# Altium schematic sheet 9xVL53L1X.SchDoc
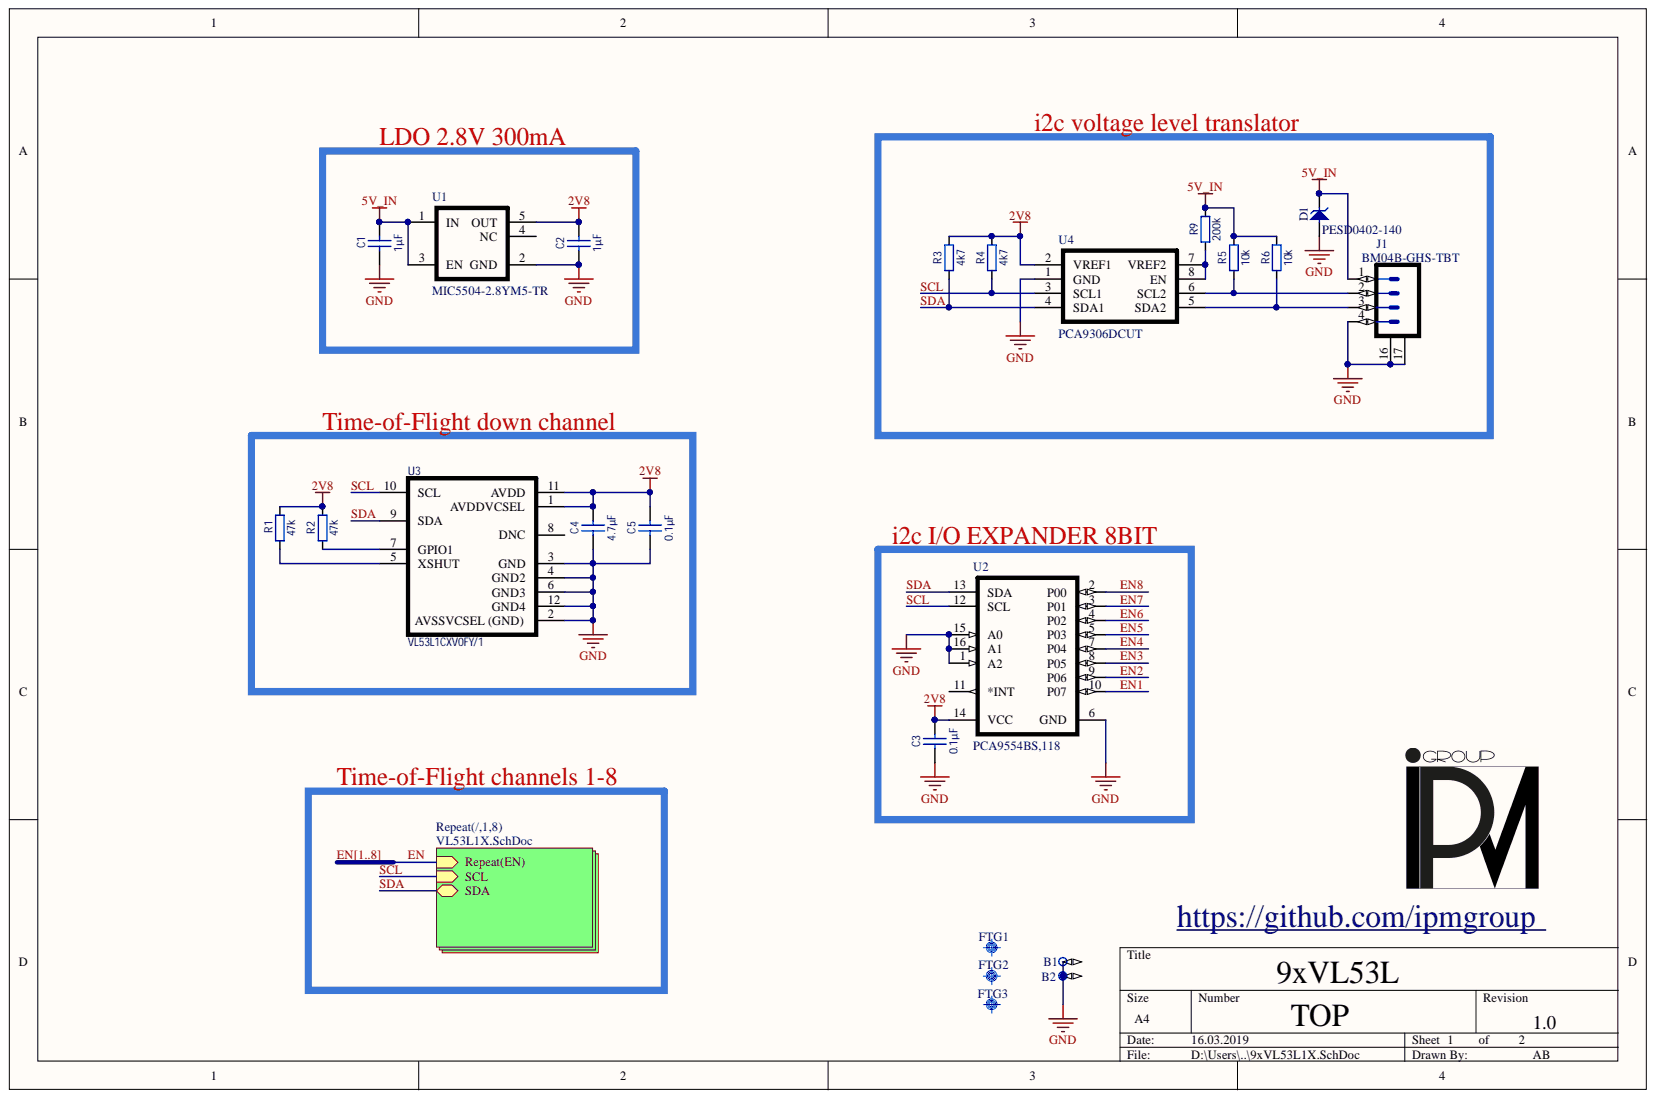
[diagram p0: 9xVL53L1X.SchDoc]

## Parts
B1  Plated Hole  [c300h160]  (value not drawn)  (no BOM)
B2  Plated Hole  [c300h160]  (value not drawn)  (no BOM)
C1  1µF  [CAPC1005X55N]
C2  1µF  [CAPC1005X55N]
C3  0.1µF  [CAPC1005X55N]
C4  4.7µF  [CAPC1005X55N]
C5  0.1µF  [CAPC1005X55N]
D1  PESD0402-140  [0402]
FTG1  Use only in PCB  [FIDUCIAL_TOP_C100-200]  (value not drawn)  (no BOM)
FTG2  Use only in PCB  [FIDUCIAL_TOP_C100-200]  (value not drawn)  (no BOM)
FTG3  Use only in PCB  [FIDUCIAL_TOP_C100-200]  (value not drawn)  (no BOM)
J1  BM04B-GHS-TBT  [JST_BM04B]
R1  47k  [RESC1005X40N]
R2  47k  [RESC1005X40N]
R3  4k7  [RESC1005X40N]
R4  4k7  [RESC1005X40N]
R5  10k  [RESC1005X40N]
R6  10k  [RESC1005X40N]
R9  200k  [RESC1005X40N]
U1  MIC5504-2.8YM5-TR  [SOT95P280X145-5N]
U2  PCA9554BS,118  [QFN65P400X400X100_HS-17N]
U3  VL53L1CXV0FY/1  [LGA12C80P3X5_250X490X156]
U4  PCA9306DCUT  [DCU8]

## Nets
2V8: C2.2 C3.2 C4.2 C5.2 R1.2 R2.2 R3.2 R4.2 U1.5(OUT) U2.14(VCC) U3.1(AVDDVCSEL) U3.11(AVDD) U4.2(VREF1)
5V_IN: C1.2 D1.1 J1.1 R5.2 R6.2 R9.2 U1.1(IN) U1.3(EN)
GND: B1.1 B2.1 C1.1 C2.1 C3.1 C4.1 C5.1 D1.2 J1.4 J1.16 J1.17 U1.2(GND) U2.1(A2) U2.6(GND) U2.15(A0) U2.16(A1) U3.2(AVSSVCSEL_(GND)) U3.3(GND) U3.4(GND2) U3.6(GND3) U3.12(GND4) U4.1(GND)
EN1: U2.10(P07)  [also labelled EN]
EN2: U2.9(P06)  [also labelled EN]
EN3: U2.8(P05)  [also labelled EN]
EN4: U2.7(P04)  [also labelled EN]
EN5: U2.5(P03)  [also labelled EN]
EN6: U2.4(P02)  [also labelled EN]
EN7: U2.3(P01)  [also labelled EN]
EN8: U2.2(P00)  [also labelled EN]
SCL: R4.1 U2.12(SCL) U3.10(SCL) U4.3(SCL1)
SDA: R3.1 U2.13(SDA) U3.9(SDA) U4.4(SDA1)
NetJ1_2: J1.2 R5.1 U4.6(SCL2)
NetJ1_3: J1.3 R6.1 U4.5(SDA2)
NetR1_1: R1.1 U3.5(XSHUT)
NetR2_1: R2.1 U3.7(GPIO1)
NetR9_1: R9.1 U4.7(VREF2) U4.8(EN)

Not connected: U1 4(NC); U2 11(*INT); U3 8(DNC)

## Library data of the parts
B1 B2 = PLATED_HOLE1.6_PAD3.0: Single Terminal Socket; Part Description: Plated Through Hole: Hole Dia.=1.6mm Pad Dia.=3.0mm; Database Table Name: Pads; Mounted: No; ComponentHeight: 0mm; Component Type: Standard (No BOM); CreateDate: 05.11.2009; Family: Plated Hole; HelpURL: Undefined; LatestRevisionDate: 05.11.2009; Library Name: Eletro-mechanical.DbLib; PackageDescription: Plated Through Hole: Hole Dia.=1.6mm Pad Dia.=3.0mm; Part Number: PLATED_HOLE1.6_PAD3.0; Pin Count: 1; Sense: No; SMD: No; Socket: No; Status: None; Author: CERN DEM JMW
C1 C2 = C0402_1u 6.3V: CAP, CERM, 0402, 1 µF, 6.3 V, ± 10%, X5R; Manufacturer: YAGEO; Manufacturer Part Number: CC0402KRX5R5BB105; Cap_F: 0,000001; Database Table Name: Capacitor_AB; Value: 1uF; Voltage: 6.3V; Case: 0402; Component Height: 0.55mm; Component Kind: Standard; Designator: C0402_1u 6.3V; Dielectric: X5R; Library Name: Capacitor_AB.DBLib; Part Description: CAP, CERM, 0402, 1 µF, 6.3 V, ± 10%, X5R; Part Number: C0402_1u 6.3V; Pin Count: 2; SMD: Yes; Supplier 1: Farnell; Supplier 2: RSComponents; Supplier 3: Digi-Key; Supplier Part Number 1: 9402063; Supplier Part Number 3: 311-1438-1-ND; Tolerance: ±10%; ValueDisplayed: 1µF
C3 C5 = C0402_100nF 16V: CAP, CERM, 0402, 0.1 µF, 16 V, ± 10%, X7R; Manufacturer: WALSIN; Manufacturer1: Samsung; Manufacturer1 Part Number: CL05B104KO5NNNC; Manufacturer Part Number: 0402B104K160CT; Cap_F: 0,0000001; Database Table Name: Capacitor_AB; Value: 0.1uF; Voltage: 16V; Case: 0402; Component Height: 0.55mm; Component Kind: Standard; Designator: C0402_100nF 16V; Dielectric: X7R; Library Name: Capacitor_AB.DBLib; Part Description: CAP, CERM, 0402, 0.1 µF, 16 V, ± 10%, X7R; Part Number: C0402_100nF 16V; Pin Count: 2; SMD: Yes; Supplier 1: Farnell; Supplier 2: RSComponents; Supplier 3: Digi-Key; Supplier Part Number 1: 2496771; Supplier Part Number 3: 1276-1001-1-ND; Tolerance: ±10%; ValueDisplayed: 0.1µF
C4 = C0402_4u7 6.3V: CAP, CERM, 0402, 4.7 µF, 6.3 V, ± 20%, X5R; Manufacturer: MURATA; Manufacturer Part Number: GRM155R60J475ME47D; Cap_F: 0,0000047; Database Table Name: Capacitor_AB; Value: 4.7uF; Voltage: 6.3V; Case: 0402; Component Height: 0.55mm; Component Kind: Standard; Designator: C0402_4u7 6.3V; Dielectric: X5R; Library Name: Capacitor_AB.DBLib; Part Description: CAP, CERM, 0402, 4.7 µF, 6.3 V, ± 20%, X5R; Part Number: C0402_4u7 6.3V; Pin Count: 2; SMD: Yes; Supplier 1: Farnell; Supplier 2: RSComponents; Supplier 3: Digi-Key; Supplier Part Number 1: 2362092; Supplier Part Number 3: 490-5915-1-ND; Tolerance: ±20%; ValueDisplayed: 4.7µF
D1 = PESD5V0S1UA: Transient Voltage Suppressor Diode (Uni-Directional); Manufacturer: Littelfuse; Part Description: Unidirectional ESD Protection for Transient Voltage Suppression; Database Table Name: Diodes; Power: 890W; Voltage: 14V; Case: 0402; ComponentHeight: 1.1mm; Component Kind: Standard; Library Name: ICs And Semiconductors.DbLib; Manufacturer1 Example: Littelfuse; Manufacturer1 Part Number: PESD0402-140; Manufacturer Part Number: PESD0402-140; Mounted: Yes; PackageDescription: Small Outline Diode Flat Lead (SOD-323), 1.70mm W X 1.25mm L X 1.10mm H body,  IPC Medium Density; Part Number: PESD0402-140; Pin Count: 2; SMD: Yes; Supplier 1: Farnell; Supplier 2: RSComponents; Supplier 3: Digi-Key; Supplier Part Number 1: 1843847; Supplier Part Number 2: 719-2294; Supplier Part Number 3: PESD0402-140CT-ND; Author: CERN DEM JLC
FTG1-FTG3 = FIDUCIAL_TARGET_C100-200: Fiducial Target; Part Description: Round Fiducial Target, Top Layer, 1.0mm, Top Solder 2.0mm, Keepout =2.0mm; Database Table Name: Pads; Mounted: No; ComponentHeight: 0mm; Component Type: Standard (No BOM); CreateDate: 09.02.2010; Family: Fiducial; LatestRevisionDate: 04.04.2012; Library Name: Eletro-mechanical.DbLib; PackageDescription: Round Fiducial Target, Top Layer, 1.0mm, Top Solder 2.0mm, Keepout =2.0mm; Part Number: FIDUCIAL_TARGET_C100-200; Pin Count: 1; SMD: Yes; Author: CERN DEM JLC
J1 = JST_GH_BM04: Connector 4 Male; Manufacturer: JST; Part Description: 4 Contacts - Pitch 1.25mm; ComponentHeight: 4.05.mm; Component Kind: Standard; Library Name: My_PCB.SchLib; Manufacturer1 Example: JST; Manufacturer1 Part Number: BM04B-GHS-TBT; Manufacturer Part Number: BM04B-GHS-TBT; Mounted: Yes; Part Number: BM04B-GHS-TBT; Pin Count: 4; SMD: Yes; Supplier 1: Farnell; Supplier 2: RSComponents; Supplier 3: Digi-Key; Supplier Part Number 2: 752-1769; Author: CERN DEM JLC
R1 R2 = R0402_47k: RES, 47 kohm, 50 V, 0402, 100 mW; Manufacturer: PANASONIC; Manufacturer1: VISHAY; Manufacturer1 Part Number: CRCW040247K0FKED; Manufacturer Part Number: ERJ2RKF4702X; Database Table Name: Resistor_AB; Power: 0.1W; TC: ±100ppm/°C; Tolerance: ±1%; Value: 47000; Case: 0402; Component Height: 0.4mm; Component Kind: Standard; Designator: R0402_47K; Library Name: Resistor_AB.DbLib; Part Description: RES, 47 kohm, 50 V, 0402, 100 mW; Part Number: R0402_47k; Pin Count: 2; SMD: Yes; Supplier 1: Farnell; Supplier 2: RSComponents; Supplier 3: Digi-Key; Supplier Part Number 1: 2302806; Supplier Part Number 2: 678-9361; Supplier Part Number 3: P47.0KLCT-ND; ValueDisplayed: 47k
R3 R4 = R0402_4k7: RES, 4.7 kohm, 50 V, 0402, 63 mW; Manufacturer: PANASONIC; Manufacturer Part Number: ERJ2RKF4701X; Database Table Name: Resistor_AB; Power: 0.0625W; TC: ±100ppm/°C; Tolerance: ±1%; Value: 4700; Case: 0402; Component Height: 0.4mm; Component Kind: Standard; Designator: R0402_4k7; Library Name: Resistor_AB.DbLib; Part Description: RES, 4.7 kohm, 50 V, 0402, 63 mW; Part Number: R0402_4k7; Pin Count: 2; SMD: Yes; Supplier 1: Farnell; Supplier 2: RSComponents; Supplier 3: Digi-Key; Supplier Part Number 1: 2302707; Supplier Part Number 3: P4.70KLCT-ND; ValueDisplayed: 4k7
R5 R6 = R0402_10k: RES, 10 kohm, 50 V, 0402, 63 mW; Manufacturer: MULTICOMP; Manufacturer1: TE Connectivity; Manufacturer1 Part Number: CRG0402F10K; Manufacturer Part Number: MCMR04X1002FTL; Database Table Name: Resistor_AB; Power: 0.0625W; TC: ±100ppm/°C; Tolerance: ±1%; Value: 10000; Case: 0402; Component Height: 0.4mm; Component Kind: Standard; Designator: R0402_10K; Library Name: Resistor_AB.DbLib; Part Description: RES, 10 kohm, 50 V, 0402, 63 mW; Part Number: R0402_10k; Pin Count: 2; SMD: Yes; Supplier 1: Farnell; Supplier 2: RSComponents; Supplier 3: Digi-Key; Supplier Part Number 1: 2072517; Supplier Part Number 2: 667-8848; Supplier Part Number 3: 541-3959-1-ND; ValueDisplayed: 10k
R9 = R0402_200k: RES, 200 kohm, 50 V, 0402, 63 mW; Manufacturer: PANASONIC; Manufacturer Part Number: ERJ2RKF2003X; Database Table Name: Resistor_AB; Power: 0.0625W; TC: ±100ppm/°C; Tolerance: ±1%; Value: 200000; Case: 0402; Component Height: 0.4mm; Component Kind: Standard; Designator: R0402_200K; Library Name: Resistor_AB.DbLib; Part Description: RES, 200 kohm, 50 V, 0402, 63 mW; Part Number: R0402_200k; Pin Count: 2; SMD: Yes; Supplier 1: Farnell; Supplier 2: RSComponents; Supplier 3: Digi-Key; Supplier Part Number 1: 2059185; Supplier Part Number 3: P200KLCT-ND; ValueDisplayed: 200k
U1 = MIC5504-2.8: 2.8V, 300mA LDO Linear Regulators dropout 160mV SOT-23; Manufacturer: MICROCHIP; Manufacturer1 Part Number: TLV70228DBVT; Pitch: 1.27mm; Case: SOT; Component Kind: Standard; Library Name: My_PCB.SchLib; Manufacturer1 ComponentHeight: 1.2mm; Manufacturer1 Example: Texas Instruments; Manufacturer Part Number: MIC5504-2.8YM5-TR; Mounted: Yes; PackageDescription: SOT-25-5; Part Description: 2.8V, 300mA LDO Linear Regulators dropout 160mV; Part Number: MIC5504-2.8YM5-TR; Pin Count: 5; Price: 0,0751 €; SMD: Yes; Supplier 1: Farnell; Supplier 2: RSComponents; Supplier 3: Digi-Key; Supplier Part Number 1: 2510373; Supplier Part Number 2: 862-5503; Supplier Part Number 3: 576-4763-1-ND
U2 = PCA9554BS: i2c,I/O EXPANDER, 8BIT; Manufacturer: NXP; Manufacturer1 Part Number: PCA9554ARGVR; Pitch: 0.65mm; Case: HVQFN; Component Kind: Standard; Library Name: My_PCB.SchLib; Manufacturer1 ComponentHeight: 1mm; Manufacturer1 Example: Texas Instruments; Manufacturer Part Number: PCA9554BS,118; Mounted: Yes; PackageDescription: HVQFN-16; Part Description: i2c,I/O EXPANDER, 8BIT; Part Number: PCA9554BS,118; Pin Count: 16; Price: 0,751 €; SMD: Yes; Supplier 1: Farnell; Supplier 2: RSComponents; Supplier 3: Digi-Key; Supplier Part Number 1: 2776171
U3 = VL53L1X: SENSOR TOF LASER DISTANCE TOF 4M; Manufacturer: ST; Part Description: SENSOR LASER DISTANCE TOF 4M; Pitch: 0.8mm; Case: LGA; Component Kind: Standard; Library Name: My_PCB.SchLib; Manufacturer1 ComponentHeight: 1.56mm; Manufacturer Part Number: VL53L1CXV0FY/1; Mounted: Yes; PackageDescription: LGA-12; Part Number: VL53L1CXV0FY/1; Pin Count: 12; SMD: Yes; Supplier 1: Farnell; Supplier 2: RSComponents; Supplier 3: Digi-Key; Supplier Part Number 1: 2851946; Supplier Part Number 2: 175-1110; Supplier Part Number 3: 497-17764-1-ND
U4 = PCA9306DCUT: Dual Bidirectional  I2C Bus and SMBus  Voltage-Level  Translator; Manufacturer: Texas Instruments; Part Description: dual bi-directional I²C-bus and SMBus Voltage-level Translator; Case: VSSOP; Component Kind: Standard; Library Name: My_PCB.SchLib; Manufacturer Part Number: PCA9306DCUT; Part Number: PCA9306DCUT; Pin Count: 8; Supplier 1: Farnell; Supplier 2: RSComponents; Supplier 3: Digi-Key; Supplier Part Number 1: 1053573; Supplier Part Number 2: 662-7421; Supplier Part Number 3: 296-32201-1-ND
